# Altium schematic sheet Connectors.SchDoc(Connectors)
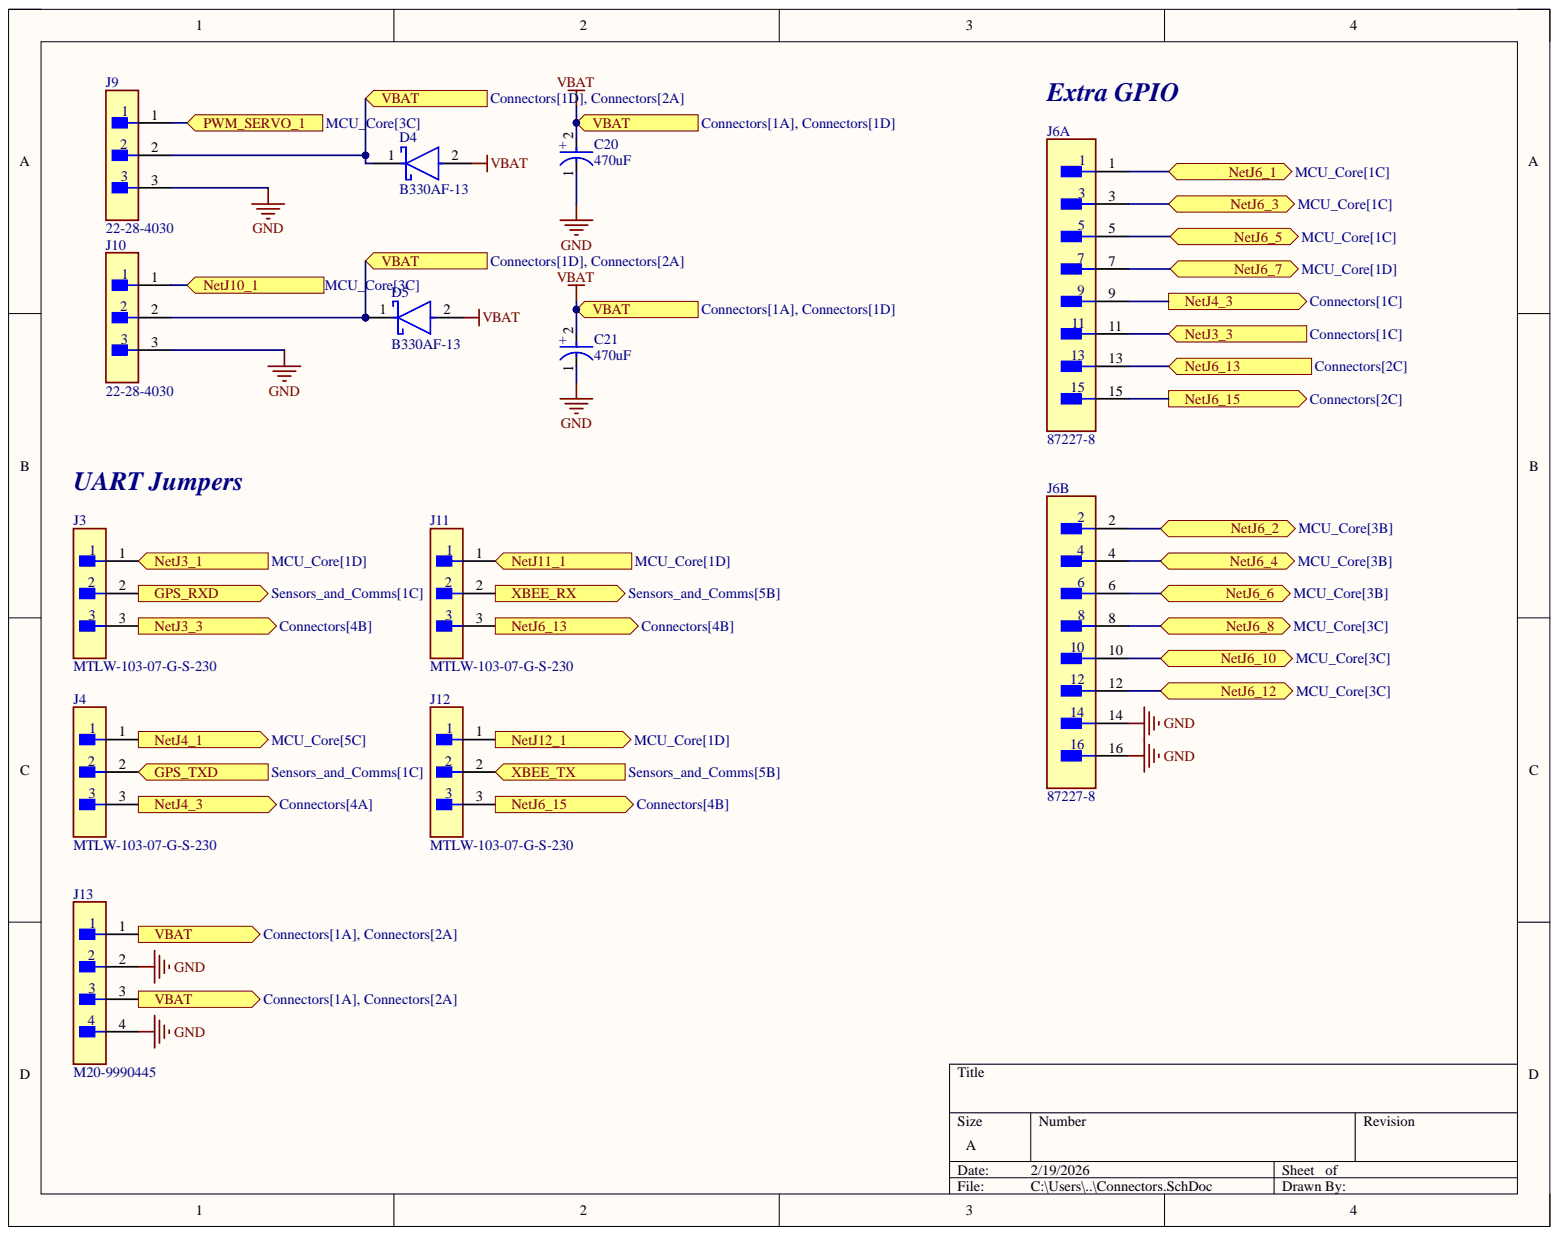
[diagram p0: Connectors.SchDoc]

## Parts
C20  470uF  [FP-EEH-G12-MFG]
C21  470uF  [FP-EEH-G12-MFG]
D4  B330AF-13  [FP-SMAF-MFG]
D5  B330AF-13  [FP-SMAF-MFG]
J3  MTLW-103-07-G-S-230  [FP-MTLW-103-07-G-S-230-MFG]
J4  MTLW-103-07-G-S-230  [FP-MTLW-103-07-G-S-230-MFG]
J6  87227-8  [FP-87227-8-MFG]  (parts A,B)
J9  22-28-4030  [FP-22-28-4030-MFG]
J10  22-28-4030  [FP-22-28-4030-MFG]
J11  MTLW-103-07-G-S-230  [FP-MTLW-103-07-G-S-230-MFG]
J12  MTLW-103-07-G-S-230  [FP-MTLW-103-07-G-S-230-MFG]
J13  M20-9990445  [FP-M20-9990445-MFG]

## Ports
GPS_RXD, GPS_TXD, Input6V_1, Input6V_2, OB_GPIO1, OB_GPIO2, OB_GPS_RX, OB_GPS_TX, OB_I2C2_SCL, OB_I2C2_SDA, OB_SPI4_CS, OB_SPI4_MISO, OB_SPI4_MOSI, OB_SPI4_SCK, OB_UART7_RX, OB_UART7_TX, OB_XBEE_RX, OB_XBEE_TX, PWM_SERVO_1, PWM_SERVO_2, STM_GPS_RX, STM_GPS_TX, STM_XBEE_RX, STM_XBEE_TX, XBEE_RX, XBEE_TX

## Nets
GND: C20.1 C21.1 J6.14 J6.16 J9.3 J10.3 J13.2 J13.4
GPS_RXD: J3.2 ; port GPS_RXD
GPS_TXD: J4.2 ; port GPS_TXD
PWM_SERVO_1: J9.1 ; port PWM_SERVO_1
VBAT: C20.2 C21.2 D4.1 D4.2 D5.1 D5.2 J9.2 J10.2 J13.1 J13.3 ; port Input6V_1, port Input6V_2
XBEE_RX: J11.2 ; port XBEE_RX
XBEE_TX: J12.2 ; port XBEE_TX
NetJ3_1: J3.1 ; port STM_GPS_TX
NetJ3_3: J3.3 J6.11 ; port OB_GPS_TX
NetJ4_1: J4.1 ; port STM_GPS_RX
NetJ4_3: J4.3 J6.9 ; port OB_GPS_RX
NetJ6_1: J6.1 ; port OB_I2C2_SCL
NetJ6_2: J6.2 ; port OB_UART7_RX
NetJ6_3: J6.3 ; port OB_I2C2_SDA
NetJ6_4: J6.4 ; port OB_UART7_TX
NetJ6_5: J6.5 ; port OB_GPIO1
NetJ6_6: J6.6 ; port OB_SPI4_CS
NetJ6_7: J6.7 ; port OB_GPIO2
NetJ6_8: J6.8 ; port OB_SPI4_SCK
NetJ6_10: J6.10 ; port OB_SPI4_MISO
NetJ6_12: J6.12 ; port OB_SPI4_MOSI
NetJ6_13: J6.13 J11.3 ; port OB_XBEE_TX
NetJ6_15: J6.15 J12.3 ; port OB_XBEE_RX
NetJ10_1: J10.1 ; port PWM_SERVO_2
NetJ11_1: J11.1 ; port STM_XBEE_TX
NetJ12_1: J12.1 ; port STM_XBEE_RX

## Library data of the parts
C20 C21 = CMP-05428-001226-1: Aluminum Hybrid Polymer Capacitors 470uF 20% 25V Life 4000Hours AEC-Q200 RADIAL SMT; Component Kind: Standard; Library Name: Altium Content Vault
D4 D5 = CMP-12790-000091-1: DIODE SCHOTTKY 30V 3A SMAF; Average Rectified Current: 3A; Component Kind: Standard; Library Name: Altium Content Vault; Max Reverse Voltage (DC): 30V; Mount: Surface Mount; Packaging: Tape and Reel; RoHS Compliant: Yes
J3 J4 J11 J12 = CMP-02766-001679-1: CONN HEADER VERT 3POS 2.54MM; Gender: Male; Max Current Rating: 3A; Number of Contacts: 3; Component Kind: Standard; Contact Material: Bronze; Contact Plating: Gold; Depth: 2.48mm; Housing Color: Black; Housing Material: Polymer; Length: 7.62mm; Library Name: Altium Content Vault; Max Operating Temperature: 125°C; Min Operating Temperature: -55°C; Number of Rows: 1; Orientation: Straight; Packaging: Bulk; Pitch: 2.54mm; Radiation Hardening: No; RoHS Compliant: Yes; Termination: Solder
J6 = CMP-03369-000064-1: CONN HEADER VERT 16POS 2.54MM; Manufacturer: TE Connectivity; Conn Gender: Male; Conn Orientation: Straight; Current Rating: 3A; Number Of Contacts: 16; Automotive: No; Category: Conn; Component Kind: Standard; ComponentLink2Description: Datasheet; ComponentLink2URL: https://www.te.com/usa-en/product-87227-8.datasheet.pdf; Contact Material: Gold,Nickel; Device Class L1: Connectors; Device Class L2: Headers and Wire Housings; Device Class L3: unset; Digikey Description: CONN HEADER VERT 16POS 2.54MM; Footprint Url: https://www.te.com/commerce/DocumentDelivery/DDEController?Action=showdoc&DocId=Customer+Drawing%7F87227%7FH4%7Fpdf%7FEnglish%7FENG_CD_87227_H4.pdf%7F87227-8; Height: 0.428inch; Lead Free: Yes; Library Name: Altium Content Vault; Manufacturer Part Number: 87227-8; Mouser Description: Headers & Wire Housings UNSHRD VERT DUAL 16; Number Of Rows: 2; Octopart: https://octopart.com/87227-8-te+connectivity-39407754; Package: 0; Pitch: 2.54mm; RoHS: TRUE; Temperature: -65°C to +105°C; Temperature Range High: +105°C; Temperature Range Low: -65°C
J9 J10 = CMP-04776-000098-1: CONN HEADER VERT 3POS 2.54MM; Manufacturer: Molex; Conn Gender: Male; Conn Orientation: Vertical; Current Rating: 4A; Number Of Contacts: 3; Voltage Rating: 500V; Automotive: No; Category: Conn; Component Kind: Standard; ComponentLink2Description: Datasheet; ComponentLink2URL: https://www.molex.com/webdocs/datasheets/pdf/en-us/0022284030_PCB_HEADERS.pdf; Contact Material: Gold,Nickel; Device Class L1: Connectors; Device Class L2: Headers and Wire Housings; Device Class L3: unset; Digikey Description: CONN HEADER VERT 3POS 2.54MM; Footprint Url: https://www.molex.com/pdm_docs/sd/022284030_sd.pdf; Height: 8.88mm; Lead Free: Yes; Library Name: Altium Content Vault; Manufacturer Part Number: 22-28-4030; Mouser Description: Headers & Wire Housings 3P VERT HEADER Sn; Number Of Rows: 1; Octopart: https://octopart.com/0022284030-molex-10476094; Package: 22-28-4030; Pitch: 2.54mm; RoHS: TRUE; Temperature: -40°C to +80°C; Temperature Range High: +80°C; Temperature Range Low: -40°C
J13 = CMP-00671-05399696-1: M20-9990445 Conn Unshrouded Header HDR 4 POS 2.54mm Solder ST Thru-Hole Bulk/Loose; Connector Type: Male Pin; Contact Resistance: 25mΩ; Current Rating: 3A; Gender: Male; Insulation Resistance: 10GΩ; Number of Contacts: 4; Voltage Rating (AC): 750V; Case/Package: SIL; Color: Black; Component Kind: Standard; Contact Material: Brass, Bronze, Gold, Nickel; Contact Mating Length: 6.096mm; Contact Plating: Gold; Depth: 2.54mm; Height: 2.54mm; Housing Color: Black; Housing Material: Nylon; Lead Pitch: 2.54mm; Length: 10.16mm; Library Name: Altium Content Vault; Max Current Rating: 3A; Max Operating Temperature: 105°C; Min Operating Temperature: -40°C; Mount: Through Hole; NexarPartId: 5399696; Number of Pins: 4; Number of Positions: 4; Number of Rows: 1; Orientation: Straight; Pitch: 2.54mm; Radiation Hardening: No; REACH SVHC: No SVHC; RoHS: Compliant; Termination: Solder; Voltage Rating (DC): 750V; Weight: 45.359237g; Width: 12.7mm
